# Altium schematic sheet robot_control_pcb.SchDoc
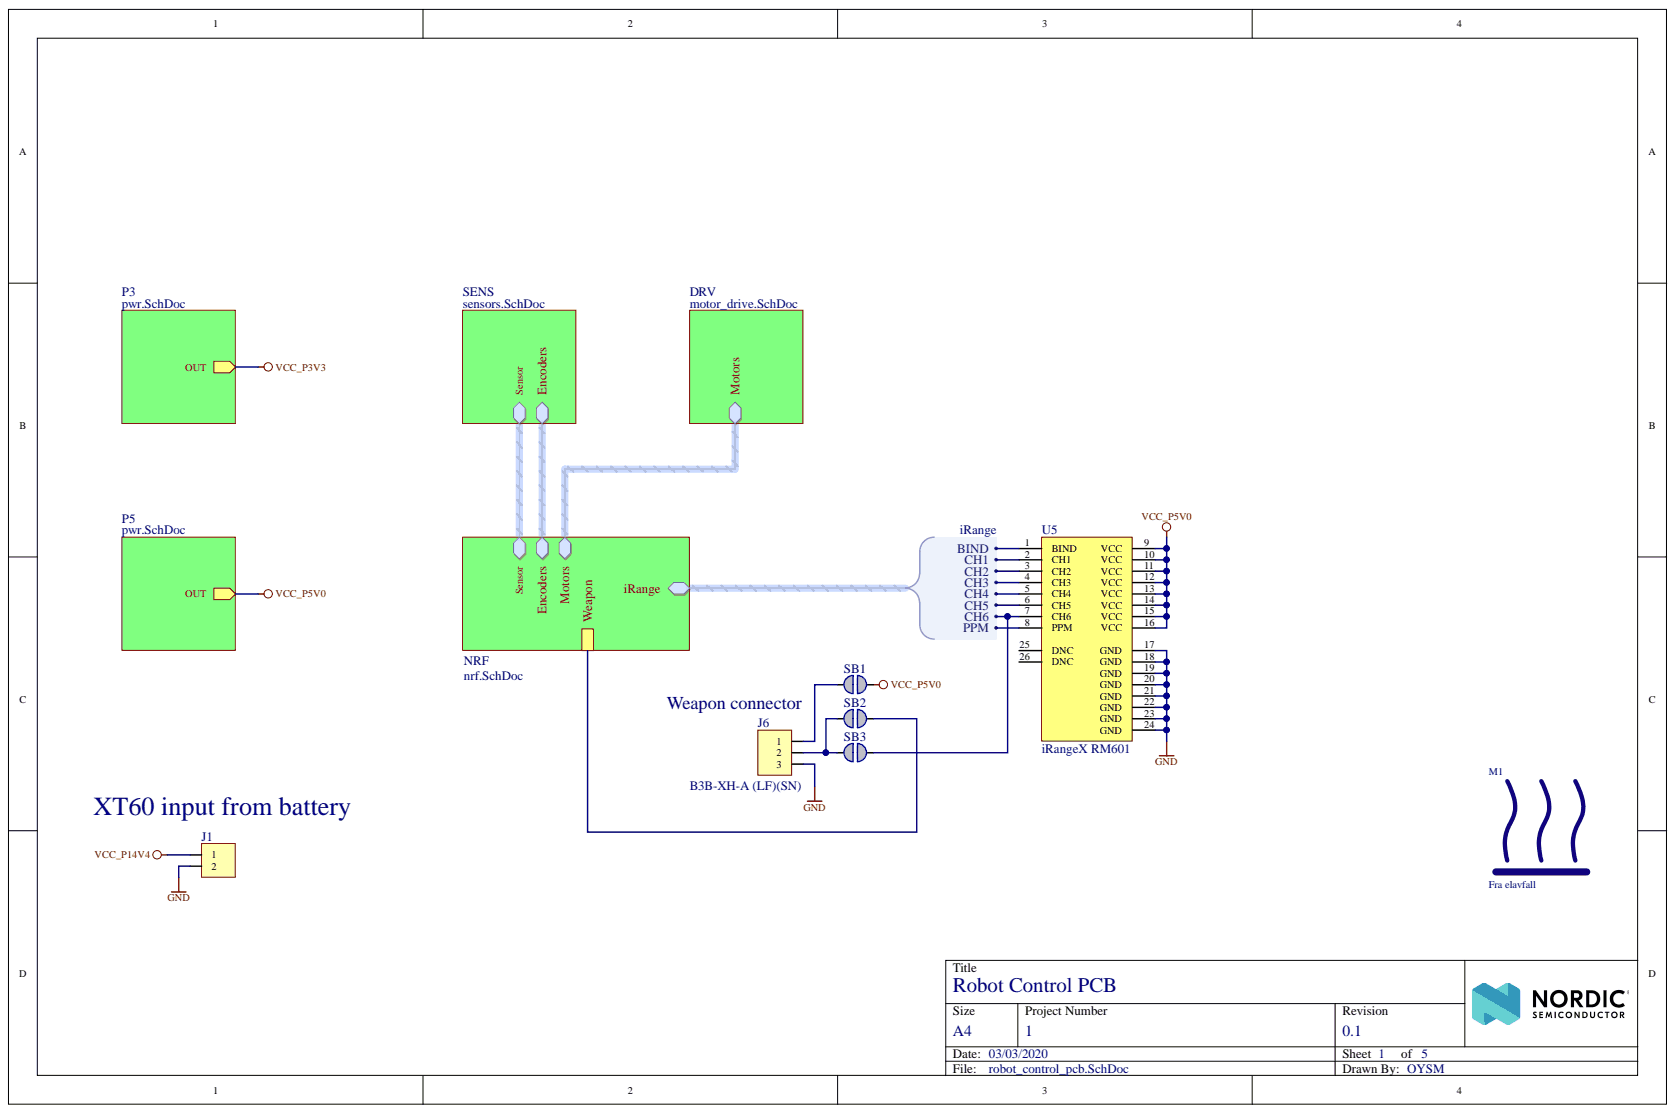
[diagram p0: robot_control_pcb.SchDoc]

## Parts
J1  =navn  [XT60_Male_Vertical]
J6  B3B-XH-A (LF)(SN)  [JST_3P]
M1  Fra elavfall  [Heatsink_36x31x09]
SB1  Solderbridge  [Solderbridge]  (value not drawn)  (no BOM)
SB2  Solderbridge  [Solderbridge]  (value not drawn)  (no BOM)
SB3  Solderbridge  [Solderbridge]  (value not drawn)  (no BOM)
U5  iRangeX RM601  [iRangeX RM601]

## Nets
GND: J1.2 J6.3 U5.17(GND) U5.18(GND) U5.19(GND) U5.20(GND) U5.21(GND) U5.22(GND) U5.23(GND) U5.24(GND)
VCC_P5V0: SB1.1 U5.9(VCC) U5.10(VCC) U5.11(VCC) U5.12(VCC) U5.13(VCC) U5.14(VCC) U5.15(VCC) U5.16(VCC)
VCC_P14V4: J1.1
NetJ6_1: J6.1 SB1.2
NetJ6_2: J6.2 SB2.2 SB3.2
NetSB2_1: SB2.1
NetSB3_1: SB3.1 U5.7(CH6)
NetU5_1: U5.1(BIND)
NetU5_2: U5.2(CH1)
NetU5_3: U5.3(CH2)
NetU5_4: U5.4(CH3)
NetU5_5: U5.5(CH4)
NetU5_6: U5.6(CH5)
NetU5_8: U5.8(PPM)
NetU5_25: U5.25(DNC)
NetU5_26: U5.26(DNC)
Encoders.Left_A:
Encoders.Left_B:
Encoders.Right_A:
Encoders.Right_B:
Motors.Enable A:
Motors.Enable B:
Motors.Input 1:
Motors.Input 2:
Motors.Input 3:
Motors.Input 4:
Sensor.AD0/SDO:
Sensor.~{CS}:
Sensor.INT:
Sensor.SCL/SCLK:
Sensor.SDA/SDI:
VCC_P3V3:

## Library data of the parts
J1 = 4544: For å koble ledninger sammen; Database Table Name: altium_Konnektorer; Component Kind: Standard; id: 4544; Library Name: OVLib_64.SVNDbLib
J6 = 4473: JST 3pin (pris pr. stk.); antall: 100; Database Table Name: altium_Konnektorer; dybde: 0; hylle: 6; komponenttype: 11; lager_type: Fremlager; leverandor: Farnell; leverandor_varenr: 1516277; nokkelord: Connector, Kontakt; pakke_opprettet_av: 815; pakketype: TH; pris: 2; rad: 3; rom: OV; Component Kind: Standard; id: 4473; Library Name: OVLib_64.SVNDbLib; navn: B3B-XH-A (LF)(SN); pakke_opprettet: 28.06.2014 18:25:12; produsent: JST; symbol_opprettet: 28.06.2014 15:33:24; symbol_opprettet_av: 815
M1 = (no symbol name): Heatsink; Component Kind: Standard; Library Name: Heatsink.SchLib
SB1-SB3 = Solderbridge: Artikkelnr Noca: N.A.; Database Table Name: Connectors$; ComponentLink1Description: Datasheet; ComponentLink2Description: Supplier link; Description: Solderbridge; Footprint Doc: Solderbridge; LatestRevisionDate: 2009-12-14; Library Name: Nordic Passive DB.DbLib; Part Number: Solderbridge; Part number IPS: N.A.; Pin Count: 2; Published: 2009-12-14; Value: Solderbridge; Publisher: Nordic Semiconductor
U5 = iRangeX RM601: Component Kind: Standard; Library Name: iRangeX RM601.SchLib
